# Altium schematic sheet acoustic_output_gaze.SchDoc
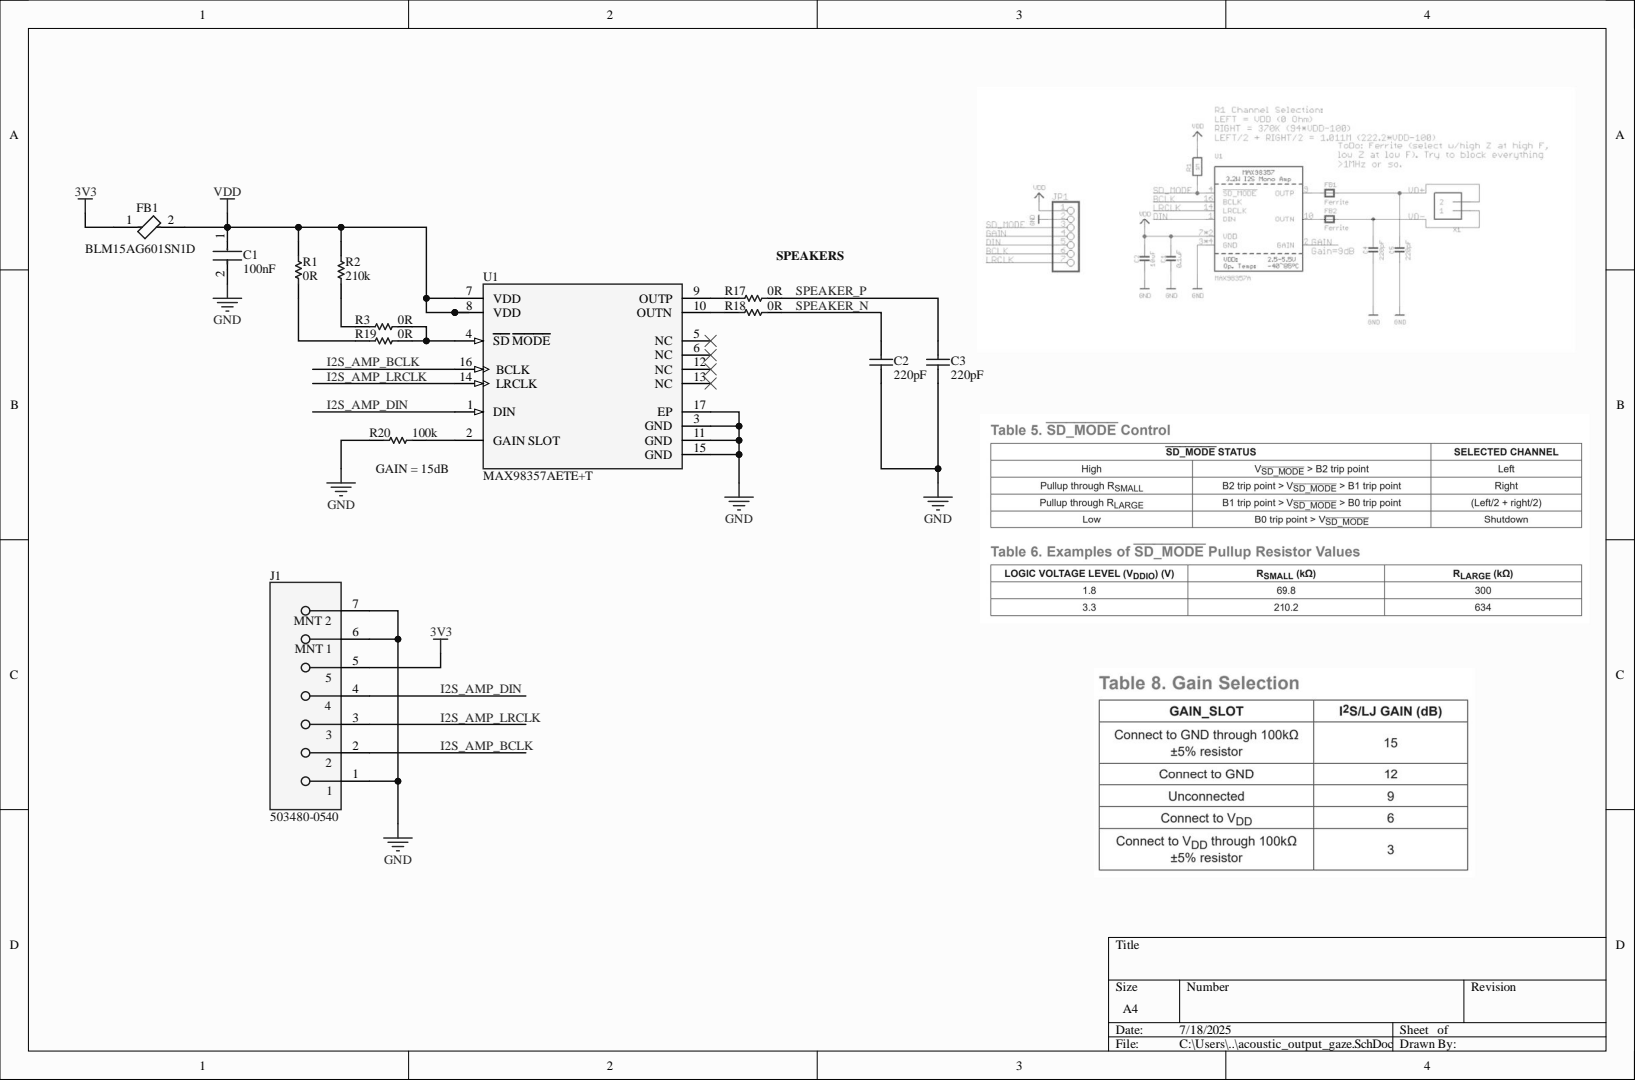
[diagram p0: acoustic_output_gaze.SchDoc]

## Parts
C1  Capacitance: 100nF  [FP-GRM15-MFG]  (hidden comment: GRM155R71C104KA88D)
C2  value 220pF  [CAPC1005X60X30NL05T10]  (hidden comment: C0402C221K5RAC7867)
C3  value 220pF  [CAPC1005X60X30NL05T10]  (hidden comment: C0402C221K5RAC7867)
FB1  BLM15AG601SN1D  [FP-BLM15-0_05-IPC_B]
J1  503480-0540  [FP-503480-0540-MFG]
R1  value 0R  [RESC1005X40X25NL05T10]  (hidden comment: ERJ-U020R00X)
R2  value 210k  [RESC1005X40X25NL05T05]  (hidden comment: CRCW0402210KFKED)
R3  value 0R  [RESC1005X40X25NL05T10]  (hidden comment: ERJ-U020R00X)
R17  value 0R  [RESC1005X40X25NL05T10]  (hidden comment: ERJ-U020R00X)
R18  value 0R  [RESC1005X40X25NL05T10]  (hidden comment: ERJ-U020R00X)
R19  value 0R  [RESC1005X40X25NL05T10]  (hidden comment: ERJ-U020R00X)
R20  value 100k  [RESC1005X40X25NL05T10]  (hidden comment: RC0402FR-07100KL)
U1  MAX98357AETE+T  Voltage Gain: 15dB  [FP-T1633-4-MFG]

## Nets
3V3: FB1.1 J1.5
GND: C1.2 C2.2 C3.2 J1.1 J1.6 J1.7 R20.2 U1.3(GND) U1.11(GND) U1.15(GND) U1.17(EP)
VDD: C1.1 FB1.2 R1.1 R2.2 U1.7(VDD) U1.8(VDD)
I2S_AMP_BCLK: J1.2 U1.16(BCLK)
I2S_AMP_DIN: J1.4 U1.1(DIN)
I2S_AMP_LRCLK: J1.3 U1.14(LRCLK)
SPEAKER_N: C2.1 R18.1
SPEAKER_P: C3.1 R17.1
NetR1_2: R1.2 R19.2
NetR2_1: R2.1 R3.2
NetR3_1: R3.1 R19.1 U1.4(SD_MODE)
NetR17_2: R17.2 U1.9(OUTP)
NetR18_2: R18.2 U1.10(OUTN)
NetR20_1: R20.1 U1.2(GAIN_SLOT)

Not connected: U1 5(NC) 6(NC) 12(NC) 13(NC)

## Library data of the parts
C1 = CMP-2100-03641-2: CAP CER 0.1UF 16V X7R 0402; Manufacturer: Murata; Material: Ceramic; Standoff Height: 0mm; Tolerance: 10%; Value: 0.10μF; Voltage Rating: 16Vdc; Category: Cap; Component Kind: Standard; ComponentLink2Description: Datasheet; ComponentLink2URL: https://search.murata.co.jp/Ceramy/image/img/A01X/G101/ENG/GRM155R71H471KA01-01A.pdf; Device Class L1: Passive Components; Device Class L2: Capacitors; Device Class L3: Ceramic Capacitors; Digikey Description: CAP CER 0.1UF 16V X7R 0402; Height: 0.55mm; Ipc Land Pattern Name: CAPC10050X219; Lead Free: yes; Library Name: Altium Content Vault; Manufacturer Part Number: GRM155R71C104KA88D; Mouser Description: 0402 0.1 uF 16V ±10 % Tolerance X7R SMT Multilayer Ceramic Capacitor; Octopart: https://octopart.com/grm155r71c104ka88d-murata-196880; Package: GRM15; RoHS: TRUE; Temperature: -55°C to +125°C; Temperature Characteristic: X7R; Temperature Range High: +125°C; Temperature Range Low: -55°C
C2 C3 = CMP-2008-03137-2: Manufacturer: Kemet; Component Kind: Standard; Library Name: Altium Content Vault; Part Number: C0402C221K5RAC7867
FB1 = CMP-0686-00187-4: Chip Ferrite Bead, 0402, 600Ω @ 100MHz, 0.52Ω, 25%, 300mA; DC Resistance (DCR): 520mR; DC Resistance-Max: 600mR; Impedance: 600R; Material: Steel; Number of Circuits: 1; Shielding: Unshielded; Case Code (Imperial): 0402; Case Code (Metric): 1005; Case/Package: 0402; Component Kind: Standard; Core Material: Ferrite; Current Rating: 300mA; DC Current: 300mA; Depth: 0.55mm; Frequency: 100MHz; Height: 0.5mm; Lead Free: Lead Free; Length: 1mm; Library Name: Altium Content Vault; Max Current Rating: 300mA; Max DC Current: 300mA; Max Operating Temperature: 125°C; Military Standard: Not; Min Operating Temperature: -55°C; Number of Lines: 1; Number of Pins: 2; Package Quantity: 10000; Packaging: Tape and Reel; Radiation Hardening: No; REACH SVHC: No SVHC; RoHS Compliant: Yes; Series: BLM15AG_SN; Termination: SMD/SMT; Test Frequency: 100MHz; Tolerance: 25%; Width: 0.5mm
J1 = CMP-00257-52963780-1: 503480-0540 Connector FFC/FPC SKT 5 Position 0.5mm Solder Right Angle Surface Mount T/R; Manufacturer Lifecycle Status: ACTIVE; Actuator Material: Glass, Nylon, Polyamide; Current Rating: 500mA; Number of Circuits: 5; Pitch: 508µm; Plating: Gold; Voltage Rating: 50V; Wire/Cable Type: Straight; Component Kind: Standard; Contact Material: Bronze, Gold, Nickel; Contact Plating: Gold; Country of Origin: KR; Flammability Rating: UL94 V-0; Halogen Free: Low Halogen; Height: 2.07mm; Housing Material: Polymer; Library Name: Altium Content Vault; Lifecycle Status: Production; Max Operating Temperature: 85°C; Min Operating Temperature: -40°C; Mount: Surface Mount; NexarPartId: 52963780; Number of Contacts: 5; Number of Positions: 5; Number of Rows: 1; Orientation: Right Angle; RoHS: Compliant; Termination: Solder
R1 R3 R17-R19 = CMP-2002-06457-1: Manufacturer: Panasonic; Component Kind: Standard; Library Name: Altium Content Vault; Part Number: ERJ-U020R00X
R2 = CMP-1011-00770-2: Manufacturer: Vishay Dale; Component Kind: Standard; Library Name: Altium Content Vault; Part Number: CRCW0402210KFKED
R20 = CMP-2002-07343-1: Manufacturer: Yageo / Phycomp; Component Kind: Standard; Library Name: Altium Content Vault; Part Number: RC0402FR-07100KL
U1 = CMP-05698-000018-1: IC AMP CLASS D MONO 3.2W 16TQFN; Max Output Current: 1.6A; Max Power Dissipation: 1.666W; Max Supply Voltage: 5.5V; Min Supply Voltage: 2.5V; Power Supply Rejection Ratio (PSRR): 77dB; Component Kind: Standard; Contact Plating: Tin; Library Name: Altium Content Vault; Max Operating Temperature: 85°C; Max Output Power: 3.2W; Min Operating Temperature: -40°C; Mount: Surface Mount; Number of Pins: 16; Packaging: Tape and Reel; Radiation Hardening: No; RoHS Compliant: Yes; Series: -
